# Altium schematic sheet DIG8.SchDoc
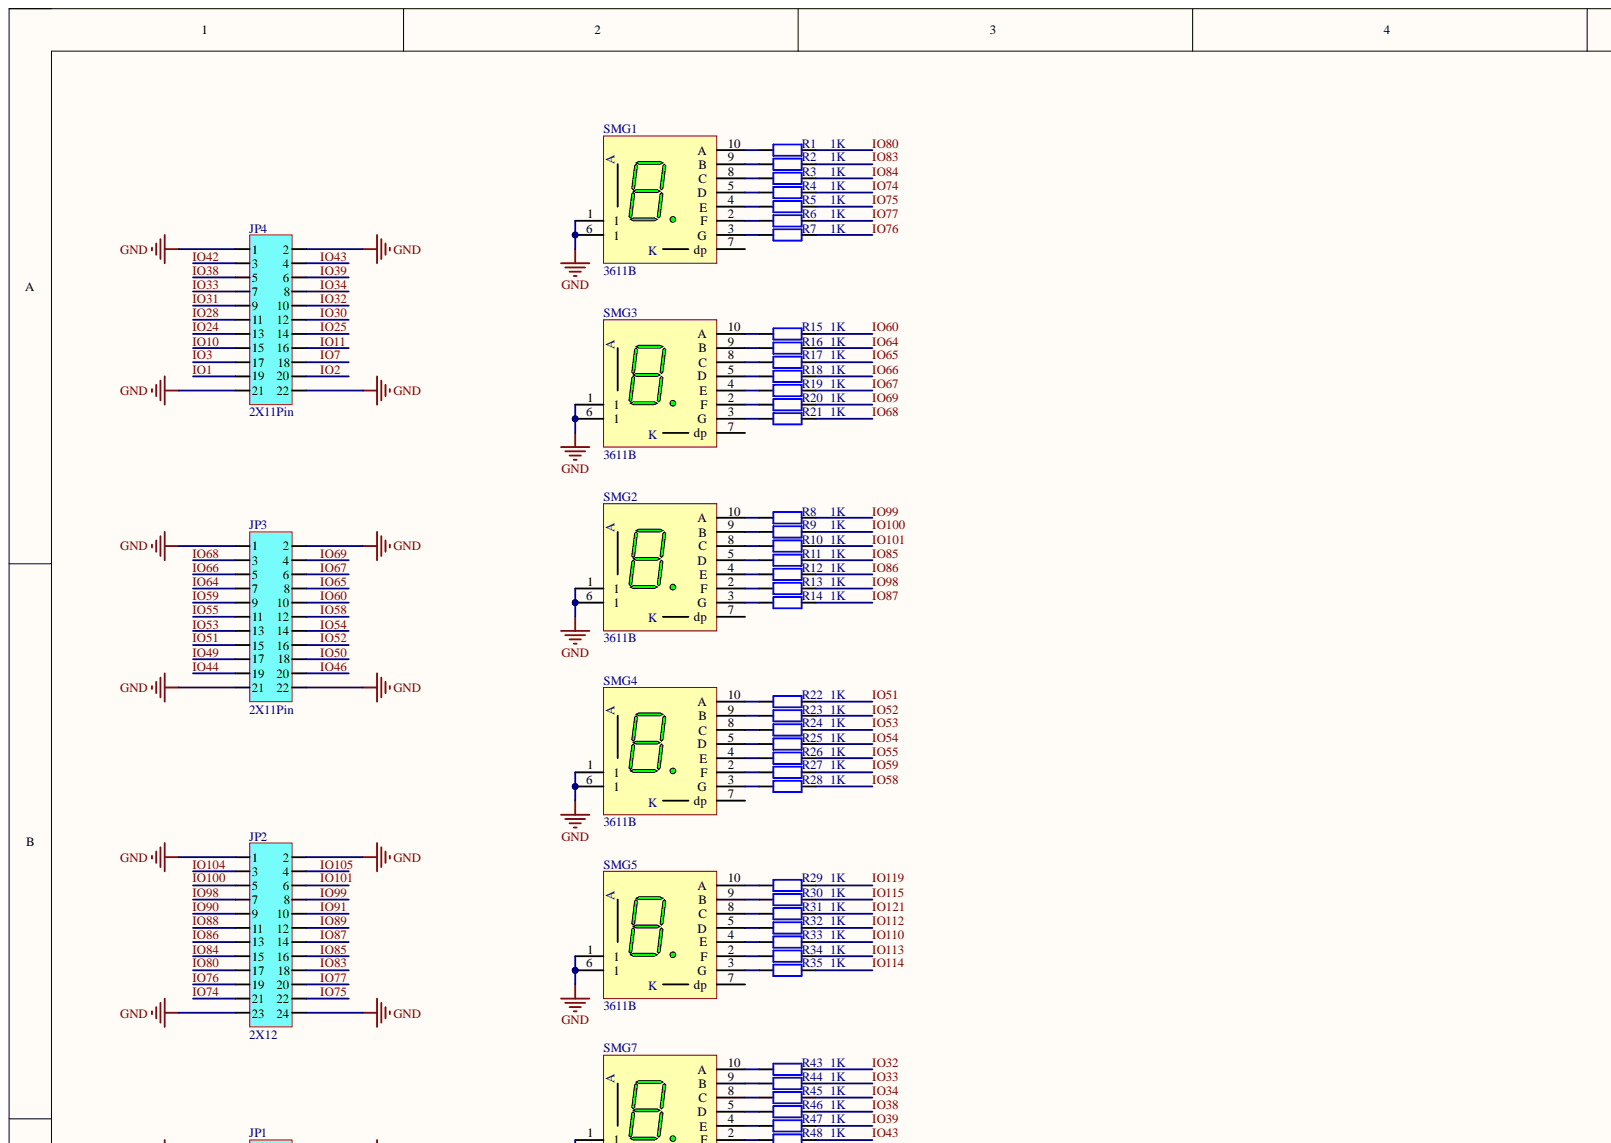
[diagram p0: DIG8.SchDoc, top left]
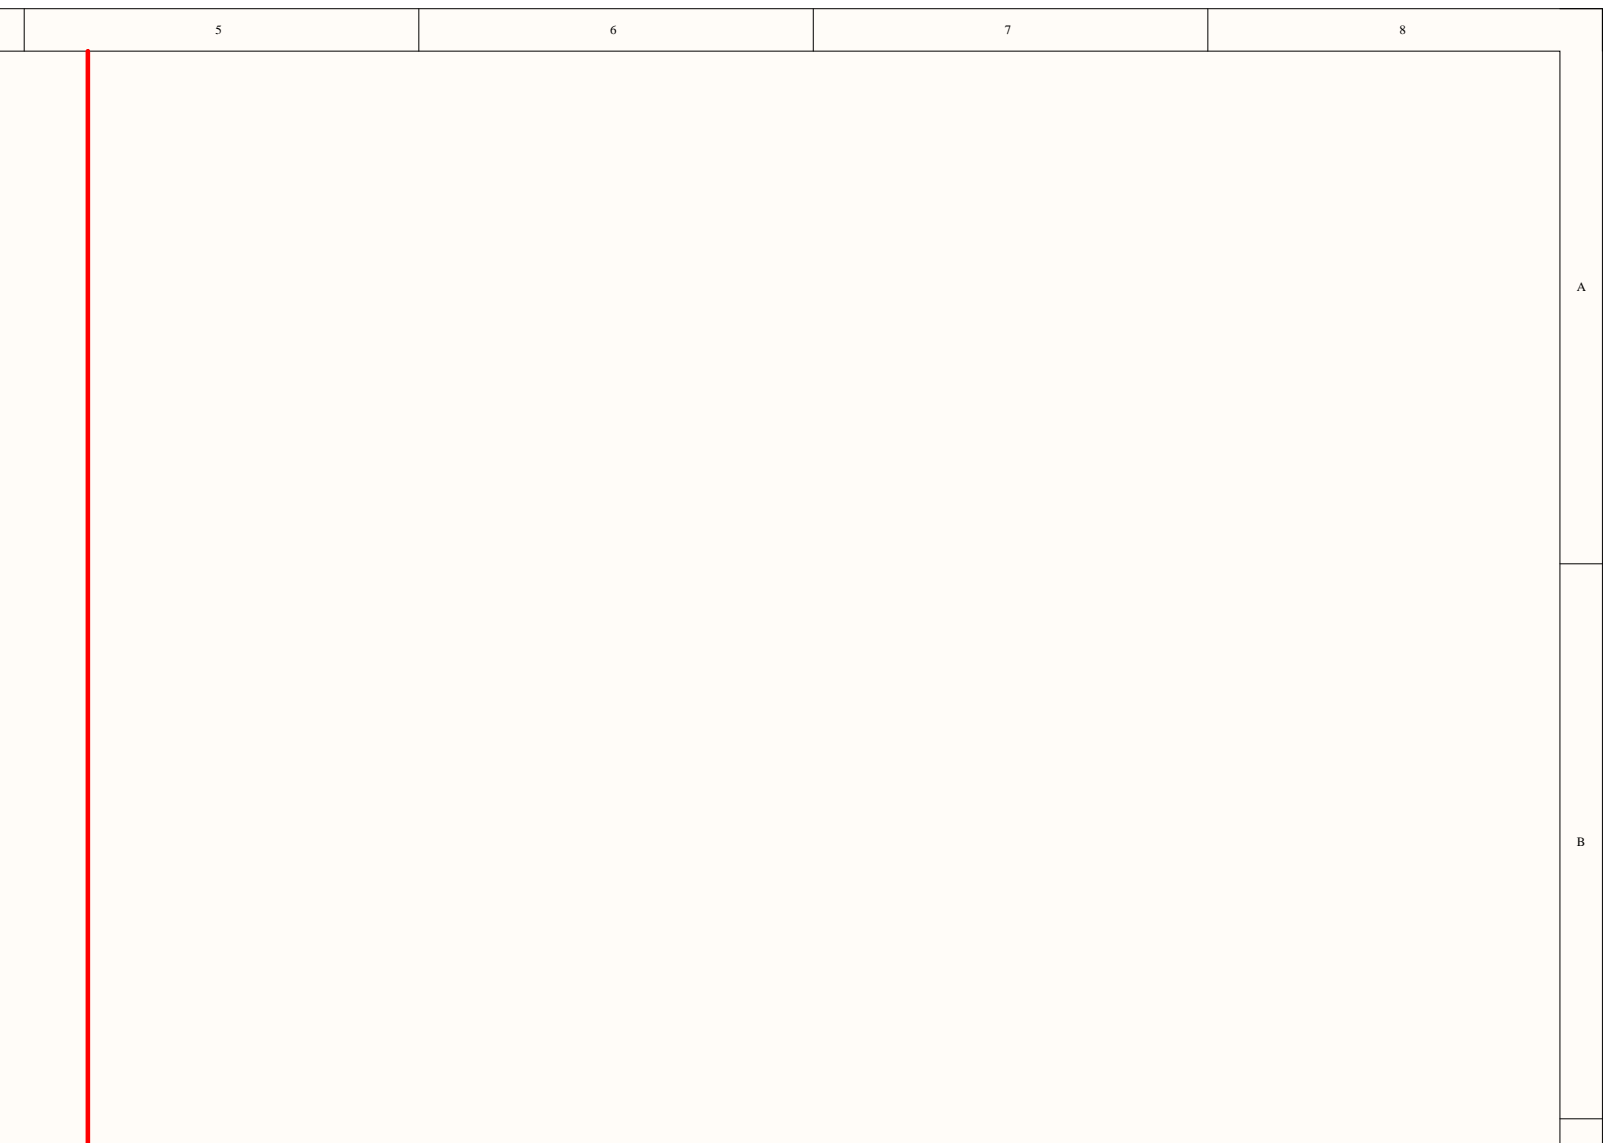
[diagram p1: DIG8.SchDoc, top right]
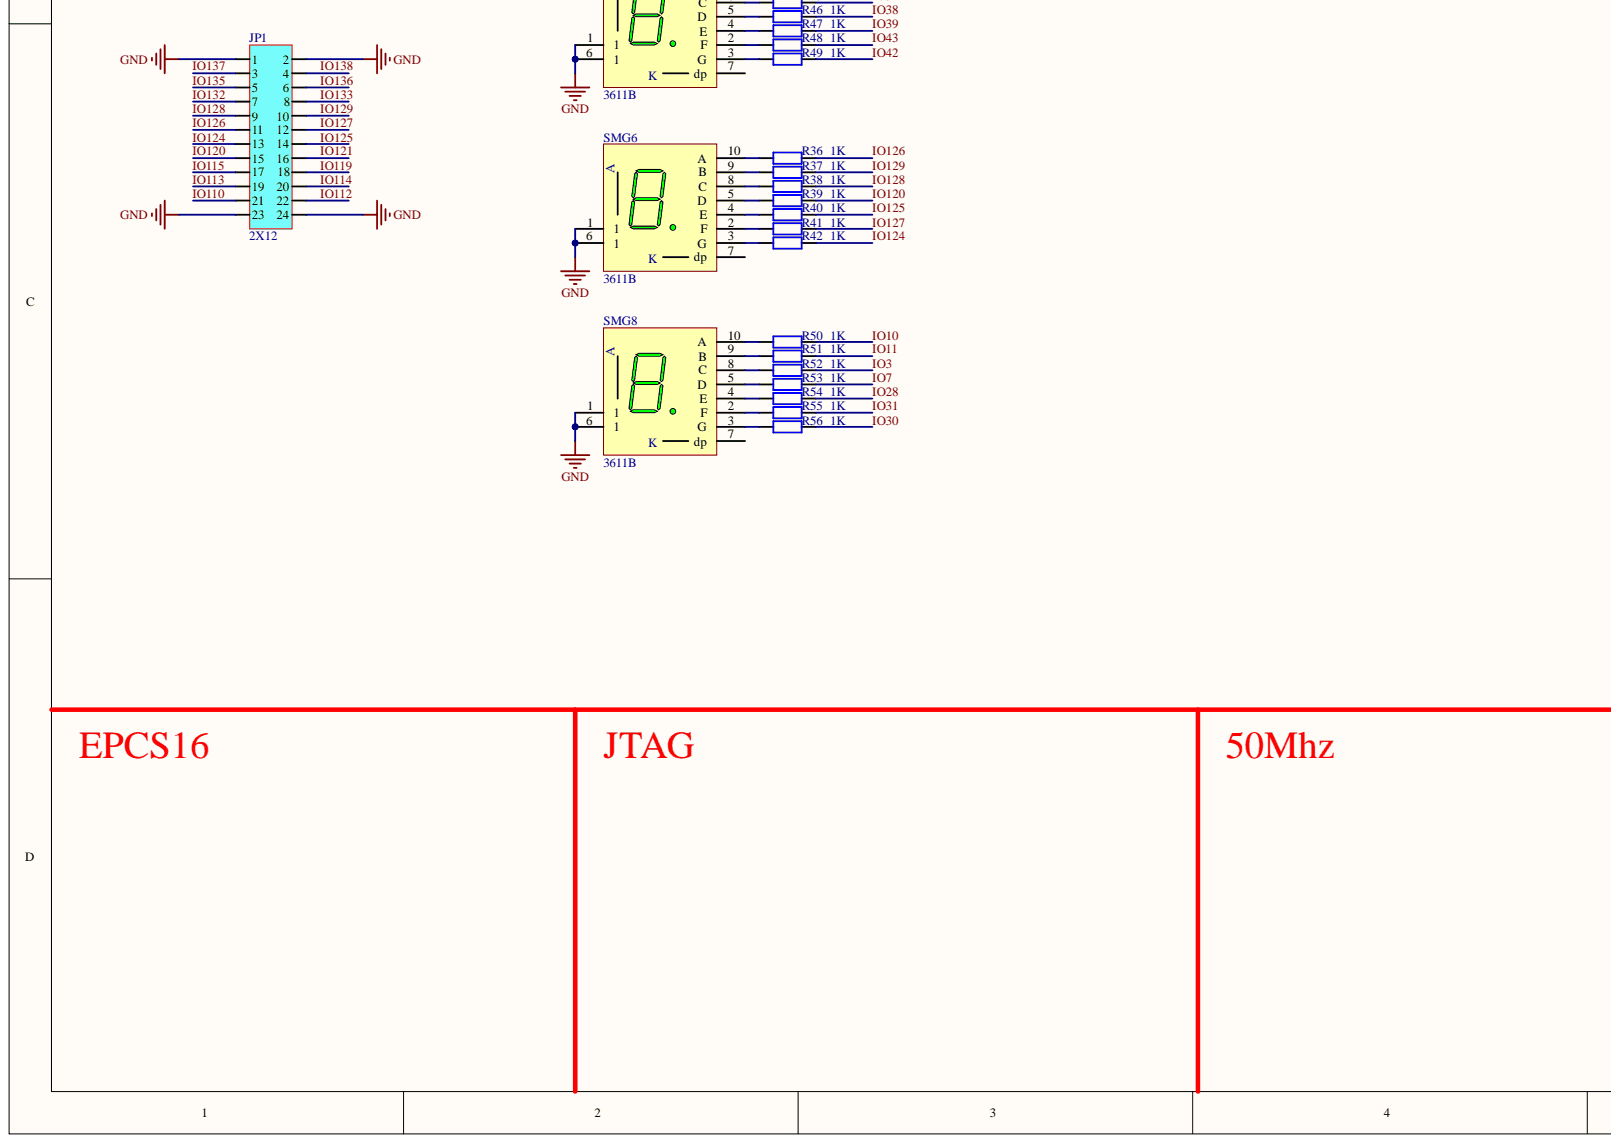
[diagram p2: DIG8.SchDoc, bottom left]
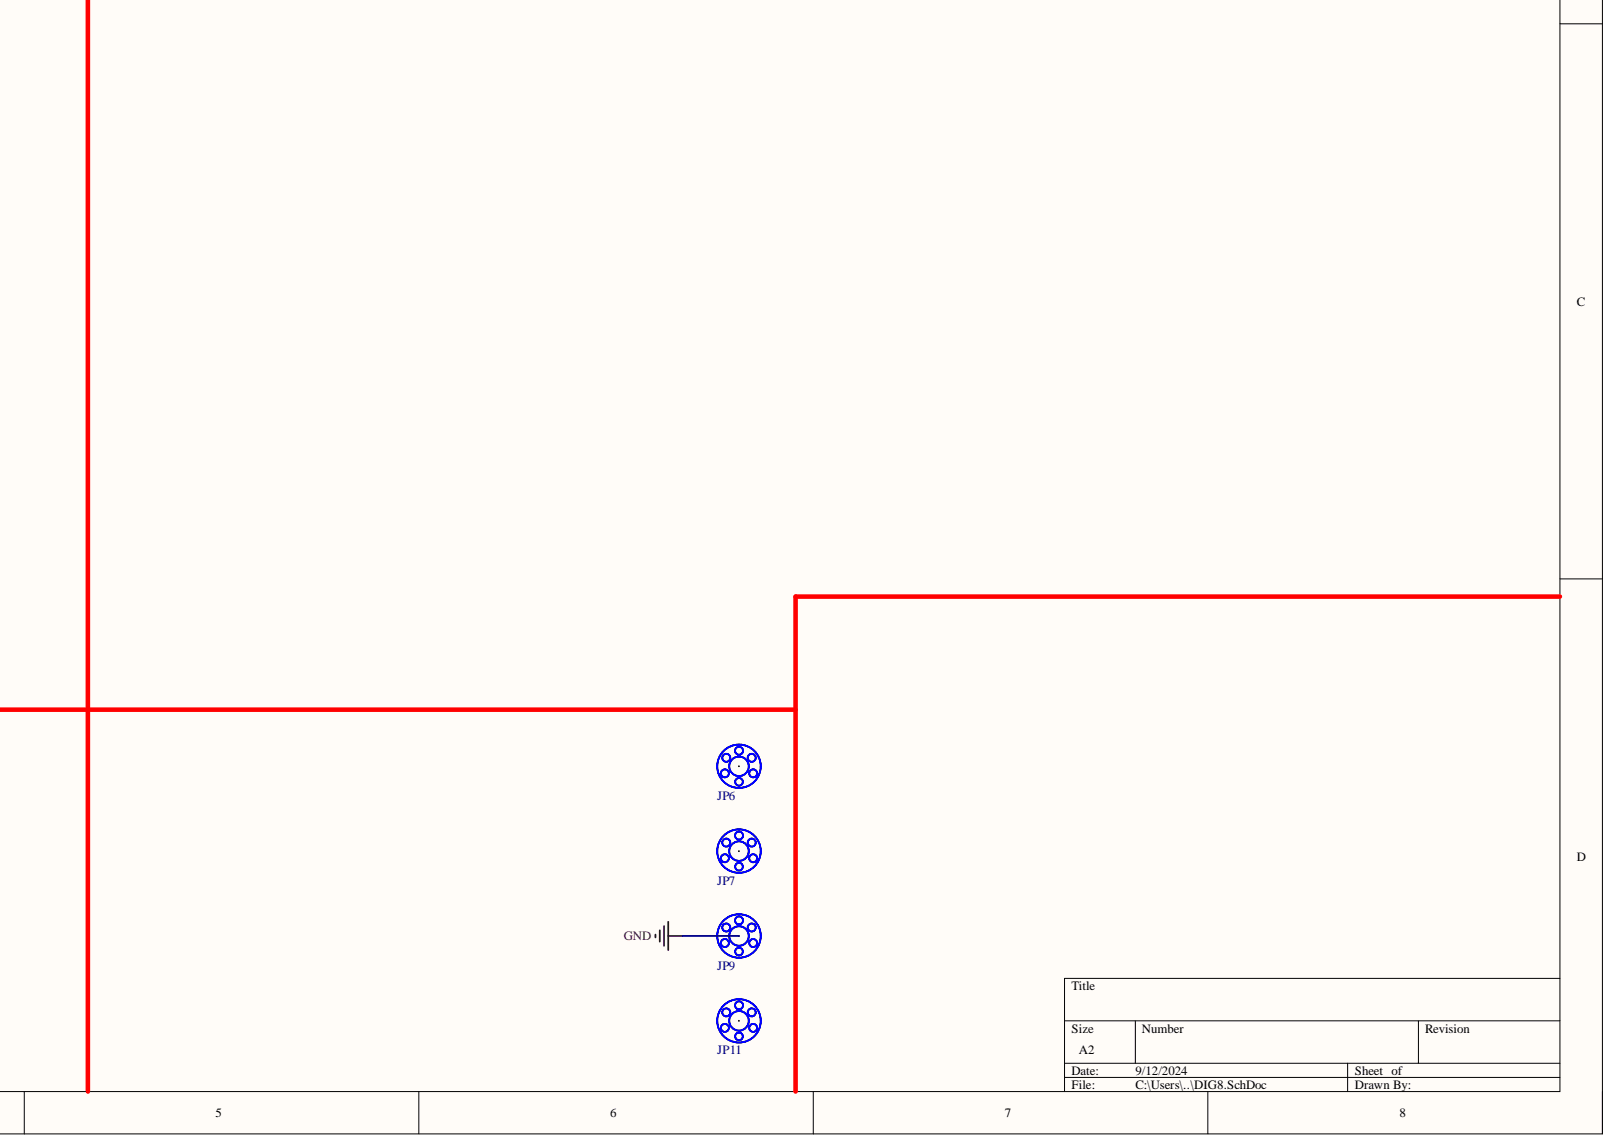
[diagram p3: DIG8.SchDoc, bottom right]

## Parts
JP1  2X12  [2X12]
JP2  2X12  [2X12]
JP3  2X11Pin  [2X11PIN]
JP4  2X11Pin  [2X11PIN]
JP6  M3定位孔  [M3]  (value not drawn)
JP7  M3定位孔  [M3]  (value not drawn)
JP9  M3定位孔  [M3]  (value not drawn)
JP11  M3定位孔  [M3]  (value not drawn)
R1  1K  [0603R]
R2  1K  [0603R]
R3  1K  [0603R]
R4  1K  [0603R]
R5  1K  [0603R]
R6  1K  [0603R]
R7  1K  [0603R]
R8  1K  [0603R]
R9  1K  [0603R]
R10  1K  [0603R]
R11  1K  [0603R]
R12  1K  [0603R]
R13  1K  [0603R]
R14  1K  [0603R]
R15  1K  [0603R]
R16  1K  [0603R]
R17  1K  [0603R]
R18  1K  [0603R]
R19  1K  [0603R]
R20  1K  [0603R]
R21  1K  [0603R]
R22  1K  [0603R]
R23  1K  [0603R]
R24  1K  [0603R]
R25  1K  [0603R]
R26  1K  [0603R]
R27  1K  [0603R]
R28  1K  [0603R]
R29  1K  [0603R]
R30  1K  [0603R]
R31  1K  [0603R]
R32  1K  [0603R]
R33  1K  [0603R]
R34  1K  [0603R]
R35  1K  [0603R]
R36  1K  [0603R]
R37  1K  [0603R]
R38  1K  [0603R]
R39  1K  [0603R]
R40  1K  [0603R]
R41  1K  [0603R]
R42  1K  [0603R]
R43  1K  [0603R]
R44  1K  [0603R]
R45  1K  [0603R]
R46  1K  [0603R]
R47  1K  [0603R]
R48  1K  [0603R]
R49  1K  [0603R]
R50  1K  [0603R]
R51  1K  [0603R]
R52  1K  [0603R]
R53  1K  [0603R]
R54  1K  [0603R]
R55  1K  [0603R]
R56  1K  [0603R]
SMG1  3611B  [SMG 0.36-1P]
SMG2  3611B  [SMG 0.36-1P]
SMG3  3611B  [SMG 0.36-1P]
SMG4  3611B  [SMG 0.36-1P]
SMG5  3611B  [SMG 0.36-1P]
SMG6  3611B  [SMG 0.36-1P]
SMG7  3611B  [SMG 0.36-1P]
SMG8  3611B  [SMG 0.36-1P]

## Nets
GND: JP1.1 JP1.2 JP1.23 JP1.24 JP2.1 JP2.2 JP2.23 JP2.24 JP3.1 JP3.2 JP3.21 JP3.22 JP4.1 JP4.2 JP4.21 JP4.22 JP9.1 SMG1.1 SMG1.6 SMG2.1 SMG2.6 SMG3.1 SMG3.6 SMG4.1 SMG4.6 SMG5.1 SMG5.6 SMG6.1 SMG6.6 SMG7.1 SMG7.6 SMG8.1 SMG8.6
IO1: JP4.19
IO2: JP4.20
IO3: JP4.17 R52.2
IO7: JP4.18 R53.2
IO10: JP4.15 R50.2
IO11: JP4.16 R51.2
IO24: JP4.13
IO25: JP4.14
IO28: JP4.11 R54.2
IO30: JP4.12 R56.2
IO31: JP4.9 R55.2
IO32: JP4.10 R43.2
IO33: JP4.7 R44.2
IO34: JP4.8 R45.2
IO38: JP4.5 R46.2
IO39: JP4.6 R47.2
IO42: JP4.3 R49.2
IO43: JP4.4 R48.2
IO44: JP3.19
IO46: JP3.20
IO49: JP3.17
IO50: JP3.18
IO51: JP3.15 R22.2
IO52: JP3.16 R23.2
IO53: JP3.13 R24.2
IO54: JP3.14 R25.2
IO55: JP3.11 R26.2
IO58: JP3.12 R28.2
IO59: JP3.9 R27.2
IO60: JP3.10 R15.2
IO64: JP3.7 R16.2
IO65: JP3.8 R17.2
IO66: JP3.5 R18.2
IO67: JP3.6 R19.2
IO68: JP3.3 R21.2
IO69: JP3.4 R20.2
IO74: JP2.21 R4.2
IO75: JP2.22 R5.2
IO76: JP2.19 R7.2
IO77: JP2.20 R6.2
IO80: JP2.17 R1.2
IO83: JP2.18 R2.2
IO84: JP2.15 R3.2
IO85: JP2.16 R11.2
IO86: JP2.13 R12.2
IO87: JP2.14 R14.2
IO88: JP2.11
IO89: JP2.12
IO90: JP2.9
IO91: JP2.10
IO98: JP2.7 R13.2
IO99: JP2.8 R8.2
IO100: JP2.5 R9.2
IO101: JP2.6 R10.2
IO104: JP2.3
IO105: JP2.4
IO110: JP1.21 R33.2
IO112: JP1.22 R32.2
IO113: JP1.19 R34.2
IO114: JP1.20 R35.2
IO115: JP1.17 R30.2
IO119: JP1.18 R29.2
IO120: JP1.15 R39.2
IO121: JP1.16 R31.2
IO124: JP1.13 R42.2
IO125: JP1.14 R40.2
IO126: JP1.11 R36.2
IO127: JP1.12 R41.2
IO128: JP1.9 R38.2
IO129: JP1.10 R37.2
IO132: JP1.7
IO133: JP1.8
IO135: JP1.5
IO136: JP1.6
IO137: JP1.3
IO138: JP1.4
NetR1_1: R1.1 SMG1.10(A)
NetR2_1: R2.1 SMG1.9(B)
NetR3_1: R3.1 SMG1.8(C)
NetR4_1: R4.1 SMG1.5(D)
NetR5_1: R5.1 SMG1.4(E)
NetR6_1: R6.1 SMG1.2(F)
NetR7_1: R7.1 SMG1.3(G)
NetR8_1: R8.1 SMG2.10(A)
NetR9_1: R9.1 SMG2.9(B)
NetR10_1: R10.1 SMG2.8(C)
NetR11_1: R11.1 SMG2.5(D)
NetR12_1: R12.1 SMG2.4(E)
NetR13_1: R13.1 SMG2.2(F)
NetR14_1: R14.1 SMG2.3(G)
NetR15_1: R15.1 SMG3.10(A)
NetR16_1: R16.1 SMG3.9(B)
NetR17_1: R17.1 SMG3.8(C)
NetR18_1: R18.1 SMG3.5(D)
NetR19_1: R19.1 SMG3.4(E)
NetR20_1: R20.1 SMG3.2(F)
NetR21_1: R21.1 SMG3.3(G)
NetR22_1: R22.1 SMG4.10(A)
NetR23_1: R23.1 SMG4.9(B)
NetR24_1: R24.1 SMG4.8(C)
NetR25_1: R25.1 SMG4.5(D)
NetR26_1: R26.1 SMG4.4(E)
NetR27_1: R27.1 SMG4.2(F)
NetR28_1: R28.1 SMG4.3(G)
NetR29_1: R29.1 SMG5.10(A)
NetR30_1: R30.1 SMG5.9(B)
NetR31_1: R31.1 SMG5.8(C)
NetR32_1: R32.1 SMG5.5(D)
NetR33_1: R33.1 SMG5.4(E)
NetR34_1: R34.1 SMG5.2(F)
NetR35_1: R35.1 SMG5.3(G)
NetR36_1: R36.1 SMG6.10(A)
NetR37_1: R37.1 SMG6.9(B)
NetR38_1: R38.1 SMG6.8(C)
NetR39_1: R39.1 SMG6.5(D)
NetR40_1: R40.1 SMG6.4(E)
NetR41_1: R41.1 SMG6.2(F)
NetR42_1: R42.1 SMG6.3(G)
NetR43_1: R43.1 SMG7.10(A)
NetR44_1: R44.1 SMG7.9(B)
NetR45_1: R45.1 SMG7.8(C)
NetR46_1: R46.1 SMG7.5(D)
NetR47_1: R47.1 SMG7.4(E)
NetR48_1: R48.1 SMG7.2(F)
NetR49_1: R49.1 SMG7.3(G)
NetR50_1: R50.1 SMG8.10(A)
NetR51_1: R51.1 SMG8.9(B)
NetR52_1: R52.1 SMG8.8(C)
NetR53_1: R53.1 SMG8.5(D)
NetR54_1: R54.1 SMG8.4(E)
NetR55_1: R55.1 SMG8.2(F)
NetR56_1: R56.1 SMG8.3(G)

Not connected: JP6 1; JP7 1; JP11 1; SMG1 7(dp); SMG2 7(dp); SMG3 7(dp); SMG4 7(dp); SMG5 7(dp); SMG6 7(dp); SMG7 7(dp); SMG8 7(dp)

## Library data of the parts
R1-R56 = 电阻: Component Kind: Standard; Library Name: DIG8.SchLib
SMG1-SMG8 = 3611B: 0.36寸1位共阳数码管; 版权归属: 源创客; BOM名称: 0.36寸1位共阳; Component Kind: Standard; Library Name: DIG8.SchLib; 学习资源: www.ooocke.com
JP1 JP2 = 2X12: Component Kind: Standard; Library Name: FPGA_EP4CE10.SchLib
JP3 JP4 = 2X11Pin: Component Kind: Standard; Library Name: FPGA_EP4CE10.SchLib
JP6 JP7 JP9 JP11 = M3定位孔: Component Kind: Standard; Library Name: FPGA_EP4CE10.SchLib
